# Altium schematic sheet TIA.SchDoc
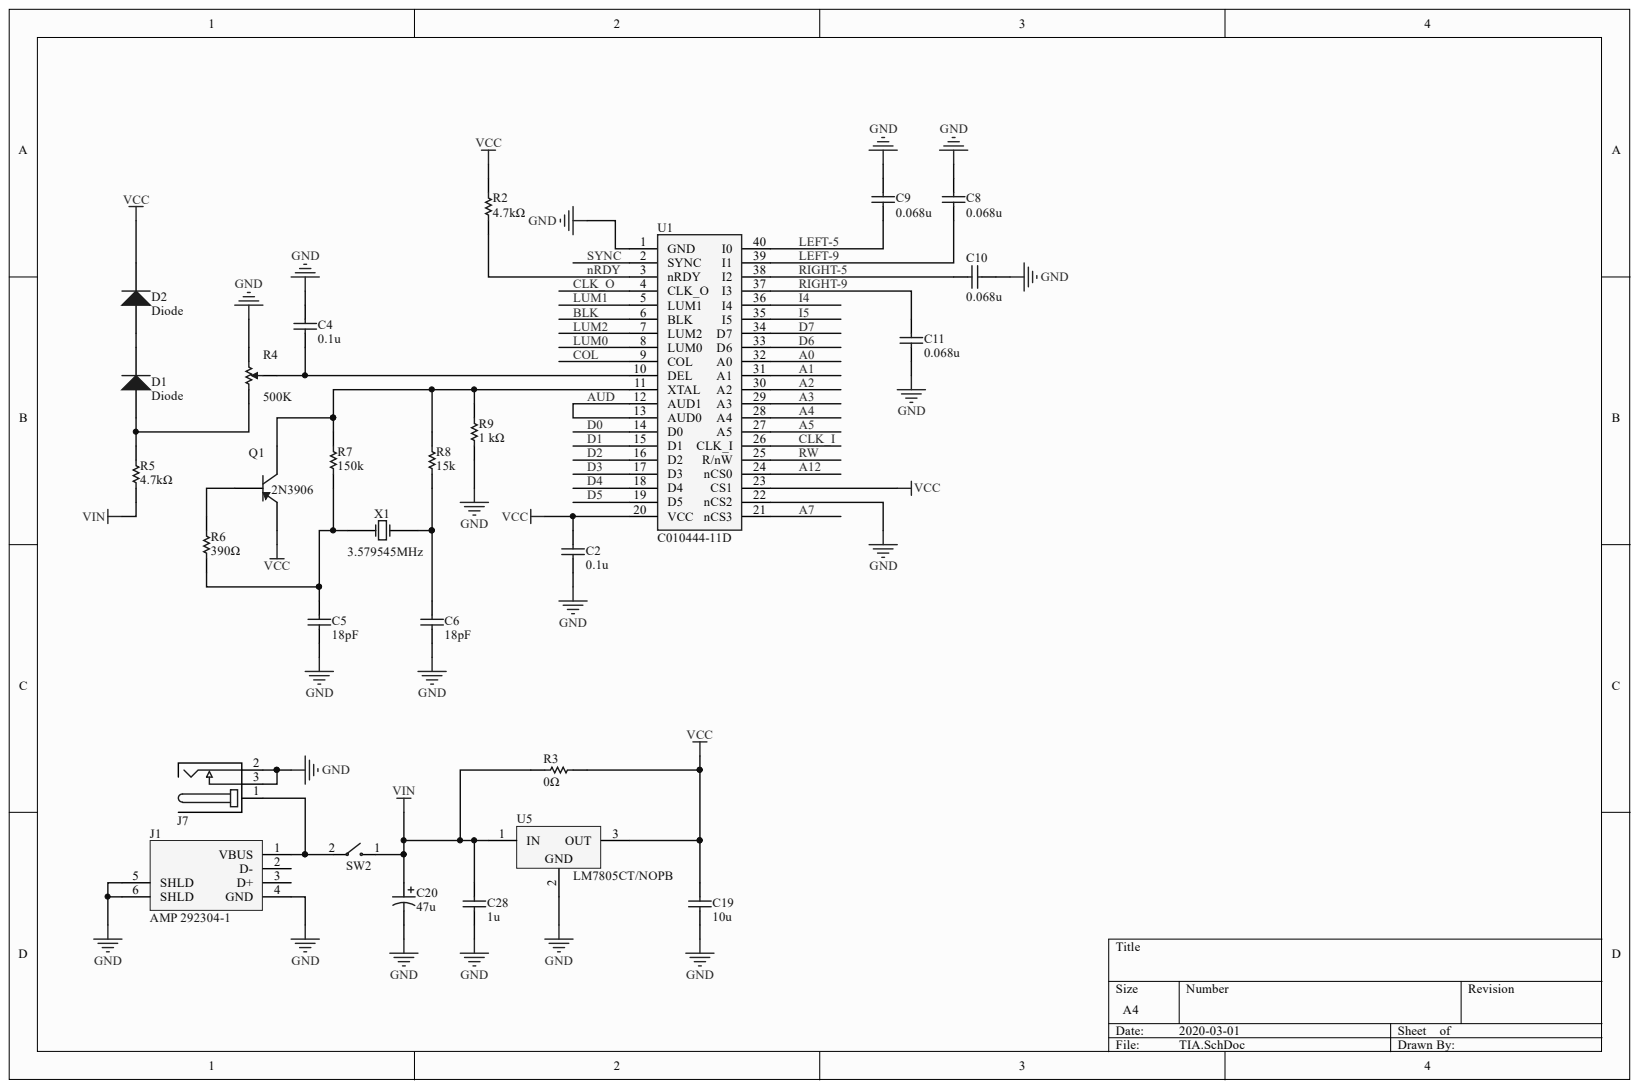
[diagram p0: TIA.SchDoc]

## Parts
C2  Capacitance: 0.1u  [CAPC2013X140X45ML15T25]  (hidden comment: Murata GCM21BR71E104KA37L)
C4  Capacitance: 0.1u  [CAPC2013X140X45ML15T25]  (hidden comment: Murata GCM21BR71E104KA37L)
C5  Capacitance: 18pF  [CAPC2013X140X45ML15T25]  (hidden comment: Murata GCM21BR71E104KA37L)
C6  Capacitance: 18pF  [CAPC2013X140X45ML15T25]  (hidden comment: Murata GCM21BR71E104KA37L)
C8  Capacitance: 0.068u  [CAPC2013X140X45ML15T25]  (hidden comment: Murata GCM21BR71E104KA37L)
C9  Capacitance: 0.068u  [CAPC2013X140X45ML15T25]  (hidden comment: Murata GCM21BR71E104KA37L)
C10  Capacitance: 0.068u  [CAPC2013X140X45ML15T25]  (hidden comment: Murata GCM21BR71E104KA37L)
C11  Capacitance: 0.068u  [CAPC2013X140X45ML15T25]  (hidden comment: Murata GCM21BR71E104KA37L)
C19  Capacitance: 10u  [CAPC2013X140X45ML15T25]  (hidden comment: Murata GCM21BR71E104KA37L)
C20  47u  [PCB-9ra1u9lng3zwvuvo58lp-1]
C28  Capacitance: 1u  [CAPC2013X140X45ML15T25]  (hidden comment: Murata GCM21BR71E104KA37L)
D1  Diode  [PCB-elufke00t2dos23v4bcp-1]
D2  Diode  [PCB-elufke00t2dos23v4bcp-1]
J1  AMP 292304-1  Manufacturer Part Number: 292304-1  [PCB-185gqpcdnvgdncegx4qx-1]
J7  CUI PJ-202A  [POWER_JACK_PTH]  (value not drawn)
Q1  2N3906  [PCB-w2y3gn6rp1r1h95bg0up-1]
R2  Resistance: 4.7kΩ  [PCB-jqva0n1m8ejtn3mkjxws-1]  (hidden comment: TE Connectivity LR1F10K)
R3  Resistance: 0Ω  [PCB-jqva0n1m8ejtn3mkjxws-1]  (hidden comment: TE Connectivity LR1F10K)
R4  Resistance: 500K  [PCB-8uuv2uwn1geg2g5qqyha-1]  (hidden comment: Bourns 3296X-1-504LF)
R5  Resistance: 4.7kΩ  [PCB-jqva0n1m8ejtn3mkjxws-1]  (hidden comment: TE Connectivity LR1F10K)
R6  Resistance: 390Ω  [PCB-jqva0n1m8ejtn3mkjxws-1]  (hidden comment: TE Connectivity LR1F10K)
R7  Resistance: 150k  [PCB-jqva0n1m8ejtn3mkjxws-1]  (hidden comment: TE Connectivity LR1F10K)
R8  Resistance: 15k  [PCB-jqva0n1m8ejtn3mkjxws-1]  (hidden comment: TE Connectivity LR1F10K)
R9  Resistance: 1 kΩ  [PCB-jqva0n1m8ejtn3mkjxws-1]  (hidden comment: TE Connectivity LR1F10K)
SW2  C&K Components L101011MS02Q  [PCB-qdmrq8mgqg6fwj7g3tos-1]  (value not drawn)
U1  C010444-11D  [PCB-0g69xcrtmvnl4lrhjmk9-1]
U5  LM7805CT/NOPB  [PCB-m217x3dwscnnq8k6ksmn-1]
X1  3.579545MHz  [XTAL-HC49S]

## Nets
GND: C2.2 C4.1 C5.2 C6.2 C8.2 C9.2 C10.2 C11.1 C19.2 C20.2 C28.2 J1.4(GND) J1.5(SHLD) J1.6(SHLD) J7.2 J7.3 R4.3 R9.1 U1.1(GND) U1.22(nCS2) U5.2
VCC: C2.1 C19.1 D2.2 Q1.1 R2.2 R3.1 U1.20(VCC) U1.23(CS1) U5.3
VIN: C20.1 C28.1 R3.2 R5.2 SW2.1 U5.1
A0: U1.32(A0)
A1: U1.31(A1)
A2: U1.30(A2)
A3: U1.29(A3)
A4: U1.28(A4)
A5: U1.27(A5)
A7: U1.21(nCS3)
A12: U1.24(nCS0)
AUD: U1.12(AUD1) U1.13(AUD0)
BLK: U1.6(BLK)
CLK_I: U1.26(CLK_I)
CLK_O: U1.4(CLK_O)
COL: U1.9(COL)
D0: U1.14(D0)
D1: U1.15(D1)
D2: U1.16(D2)
D3: U1.17(D3)
D4: U1.18(D4)
D5: U1.19(D5)
D6: U1.33(D6)
D7: U1.34(D7)
I4: U1.36(I4)
I5: U1.35(I5)
LEFT-5: C9.1 U1.40(I0)
LEFT-9: C8.1 U1.39(I1)
LUM0: U1.8(LUM0)
LUM1: U1.5(LUM1)
LUM2: U1.7(LUM2)
NRDY: R2.1 U1.3(nRDY)
RIGHT-5: C10.1 U1.38(I2)
RIGHT-9: C11.2 U1.37(I3)
RW: U1.25(R/nW)
SYNC: U1.2(SYNC)
NetC4_2: C4.2 R4.2 U1.10(DEL)
NetC5_1: C5.1 R6.2 R7.2 X1.1
NetC6_1: C6.1 R8.2 X1.2
NetD1_1: D1.1 R4.1 R5.1
NetD1_2: D1.2 D2.1
NetJ1_1: J1.1(VBUS) J7.1 SW2.2
NetQ1_2: Q1.2 R6.1
NetQ1_3: Q1.3 R7.1 R8.1 R9.2 U1.11(XTAL)

Not connected: J1 2(D-) 3(D+)

## Library data of the parts
C2 C4 = CMP-135214-2: CAP CER 0.1UF 25V 10% X7R 0805; Voltage Rating (DC): 25.0 V; Capacitance Tolerance: ±10%; Case/Package: 0805; Component Kind: Standard; Dielectric Characteristic: X7R; Library Name: CommunityVault; OctopartID: e78b2f8c9518384c; Octopart Url: https://octopart.com/gcm21br71e104ka37l-murata-17651701; RoHS: Compliant
C5 C6 = CMP-135214-2: CAP CER 18pF 25V 10% X7R 0805; Voltage Rating (DC): 25.0 V; Capacitance Tolerance: ±10%; Case/Package: 0805; Component Kind: Standard; Dielectric Characteristic: X7R; Library Name: CommunityVault; OctopartID: e78b2f8c9518384c; Octopart Url: https://octopart.com/gcm21br71e104ka37l-murata-17651701; RoHS: Compliant
C8-C11 = CMP-135214-2: CAP CER 0.068UF 25V 10% X7R 0805; Voltage Rating (DC): 25.0 V; Capacitance Tolerance: ±10%; Case/Package: 0805; Component Kind: Standard; Dielectric Characteristic: X7R; Library Name: CommunityVault; OctopartID: e78b2f8c9518384c; Octopart Url: https://octopart.com/gcm21br71e104ka37l-murata-17651701; RoHS: Compliant
C19 = CMP-135214-2: CAP CER 10UF 10V X7R 0805; Capacitance Tolerance: ±10%; Voltage Rating (DC): 25.0 V; Case/Package: 0805; Component Kind: Standard; Dielectric Characteristic: X7R; Library Name: CommunityVault; OctopartID: e78b2f8c9518384c; Octopart Url: https://octopart.com/gcm21br71e104ka37l-murata-17651701; RoHS: Compliant
C20 = CMP-245aec03ba1dfb9d-1: Cap Aluminum 47uF 25V 20% (5 X 11mm) Radial Aluminum Cylindrical Can 2mm 90mA 2000 hr 105°C Bulk; Voltage Rating (DC): 25.0 V; Component Kind: Standard; Library Name: CommunityVault; Size-Height: 11.0 mm
C28 = CMP-135214-2: CAP CER 1UF 50V X7R 0805; Capacitance Tolerance: ±10%; Voltage Rating (DC): 25.0 V; Case/Package: 0805; Component Kind: Standard; Dielectric Characteristic: X7R; Library Name: CommunityVault; OctopartID: e78b2f8c9518384c; Octopart Url: https://octopart.com/gcm21br71e104ka37l-murata-17651701; RoHS: Compliant
D1 D2 = CMP-13951708-6: FAIRCHILD SEMICONDUCTOR   1N914   DIODE, SMALL SIGNAL,100V, DO-35; Component Kind: Standard; Library Name: CommunityVault
J1 = CMP-a9ce629d318a7609-5: Surface Mount Right Angle USB B Connector; Current Rating: 1.00 A; ELV Compliant: Compliant; Gender: Female; Insulation Resistance: 1.00 GΩ; Lead-Free Status: Lead Free; Number of Contacts: 4; Number of Mating Cycles: 1500; Voltage Rating (AC): 30.0 V; Component Kind: Standard; Contact Material: Copper Alloy; Contact Plating: Gold, Gold over Nickel, Gold Flash, Gold Flash over Nickel; Flammability Rating: UL 94 V-0; Housing Color: Black; Housing Material: Thermoplastic; Library Name: CommunityVault; Lifecycle Status: Active; Mounting Style: Through Hole, Horizontal; Number of Positions: 4; OctopartID: a9ce629d318a7609; Octopart URL: http://octopart.com/292304-1-te+connectivity+%2F+amp-39435554; Orientation: Right Angle; Packaging: Tray; REACH SVHC Compliance: No SVHC; RoHS: Compliant; Size-Height: 11.5 mm; Size-Length: 15.7 mm; Size-Width: 15.7 mm
J7 = CMP-330401-10: CONN POWER JACK 2.1MM PCB; Gender: Male; Lead-Free Status: Lead Free; Mounting Angle: 90.0 °; Shielding: No; Color: Black; Component Kind: Standard; Contact Plating: Nickel; Library Name: CommunityVault; Lifecycle Status: Active; Manufacturer Part Number: PJ-202A; Mounting Style: Through Hole; OctopartID: d66e1f6093d409a9; Octopart Url: https://octopart.com/pj-202a-cui-286940; Orientation: Horizontal; Packaging: Bulk; RoHS: Compliant; Size-Diameter: 0.0021 m
Q1 = CMP-c7560e5982781891-2: Trans GP BJT PNP 40V 0.2A 3-Pin TO-92 Ammo; Manufacturer Part Number: 2N3906-AP; Case/Package: TO-92; Component Kind: Standard; Library Name: CommunityVault; Lifecycle Status: Not Listed by Manufacturer; Mounting Style: Through Hole; Octopart URL: http://octopart.com/2n3906-ap-mcc-352328; Packaging: Cut Tape (CT), Tape & Box (TB); Polarity: PNP; RoHS: Compliant
R2 R5 = CMP-0a5cc3a37cecd59b-6: Res Metal Film 4.7K Ohm; ELV Compliant: Compliant; Component Kind: Standard; Library Name: CommunityVault; Manufacturer Part Number: LR1F10K; Packaging: Ammo Pack; REACH SVHC Compliance: No SVHC; RoHS: Compliant
R3 = CMP-0a5cc3a37cecd59b-6: Res Metal Film 0 Ohm; ELV Compliant: Compliant; Component Kind: Standard; Library Name: CommunityVault; Manufacturer Part Number: LR1F10K; Packaging: Ammo Pack; REACH SVHC Compliance: No SVHC; RoHS: Compliant
R4 = CMP-793ab03899fca6fd-3: Res Cermet Trimmer 500K Ohm 10% 1/2W 25(Elec)Turn 2.19mm (11.05 X 4.83 X 10.03mm) Pin Thru-Hole Tube; Voltage Rating (dc): 300 V; Component Kind: Standard; Library Name: CommunityVault; Manufacturer Part Number: 3296X-1-504LF; Resistance Tolerance: 10%
R6 = CMP-0a5cc3a37cecd59b-6: Res Metal Film 390 Ohm; ELV Compliant: Compliant; Component Kind: Standard; Library Name: CommunityVault; Manufacturer Part Number: LR1F10K; Packaging: Ammo Pack; REACH SVHC Compliance: No SVHC; RoHS: Compliant
R7 = CMP-0a5cc3a37cecd59b-6: Res Metal Film 150k Ohm; ELV Compliant: Compliant; Component Kind: Standard; Library Name: CommunityVault; Manufacturer Part Number: LR1F10K; Packaging: Ammo Pack; REACH SVHC Compliance: No SVHC; RoHS: Compliant
R8 = CMP-0a5cc3a37cecd59b-6: Res Metal Film 15k Ohm; ELV Compliant: Compliant; Component Kind: Standard; Library Name: CommunityVault; Manufacturer Part Number: LR1F10K; Packaging: Ammo Pack; REACH SVHC Compliance: No SVHC; RoHS: Compliant
R9 = CMP-0a5cc3a37cecd59b-6: Res Metal Film 10K Ohm 1% 3/5W ±50ppm/°C Conformal AXL Thru-Hole Ammo Pack; ELV Compliant: Compliant; Component Kind: Standard; Library Name: CommunityVault; Manufacturer Part Number: LR1F10K; Packaging: Ammo Pack; REACH SVHC Compliance: No SVHC; RoHS: Compliant
SW2 = CMP-8b0d4211219154ca-3: Switch Slide SPST Top Slide 4A 250VAC 125VDC PC Pins Thru-Hole Bulk; Current Rating: 4.00 A; Component Kind: Standard; Library Name: CommunityVault; Manufacturer Part Number: L101011MS02Q; Mounting Style: Through Hole, PC Board; OctopartID: 8b0d4211219154ca; RoHS: Compliant
U1 = CMP-xoxj549pggmunnukw3my-1: ATARI TIA; Component Kind: Standard; CustomComponentID: xoxj549pggmunnukw3my; Library Name: FavoritesLibrary
U5 = CMP-8a9e42f854f65559-4: Linear voltage regulator [ST micro] LM7805CV Linear voltage regulator 2A THT; Component Kind: Standard; Library Name: CommunityVault
X1 = CMP-4bbe82b0beb59479-2: Crystal 3.579545MHz Â±20ppm (Tol) Â±50ppm (Stability) 18pF FUND 180Ohm 2-Pin HC-49/US Thru-Hole; Component Kind: Standard; Library Name: CommunityVault
